# Altium schematic sheet 2_USB_UART.SchDoc(2_USB_UART)
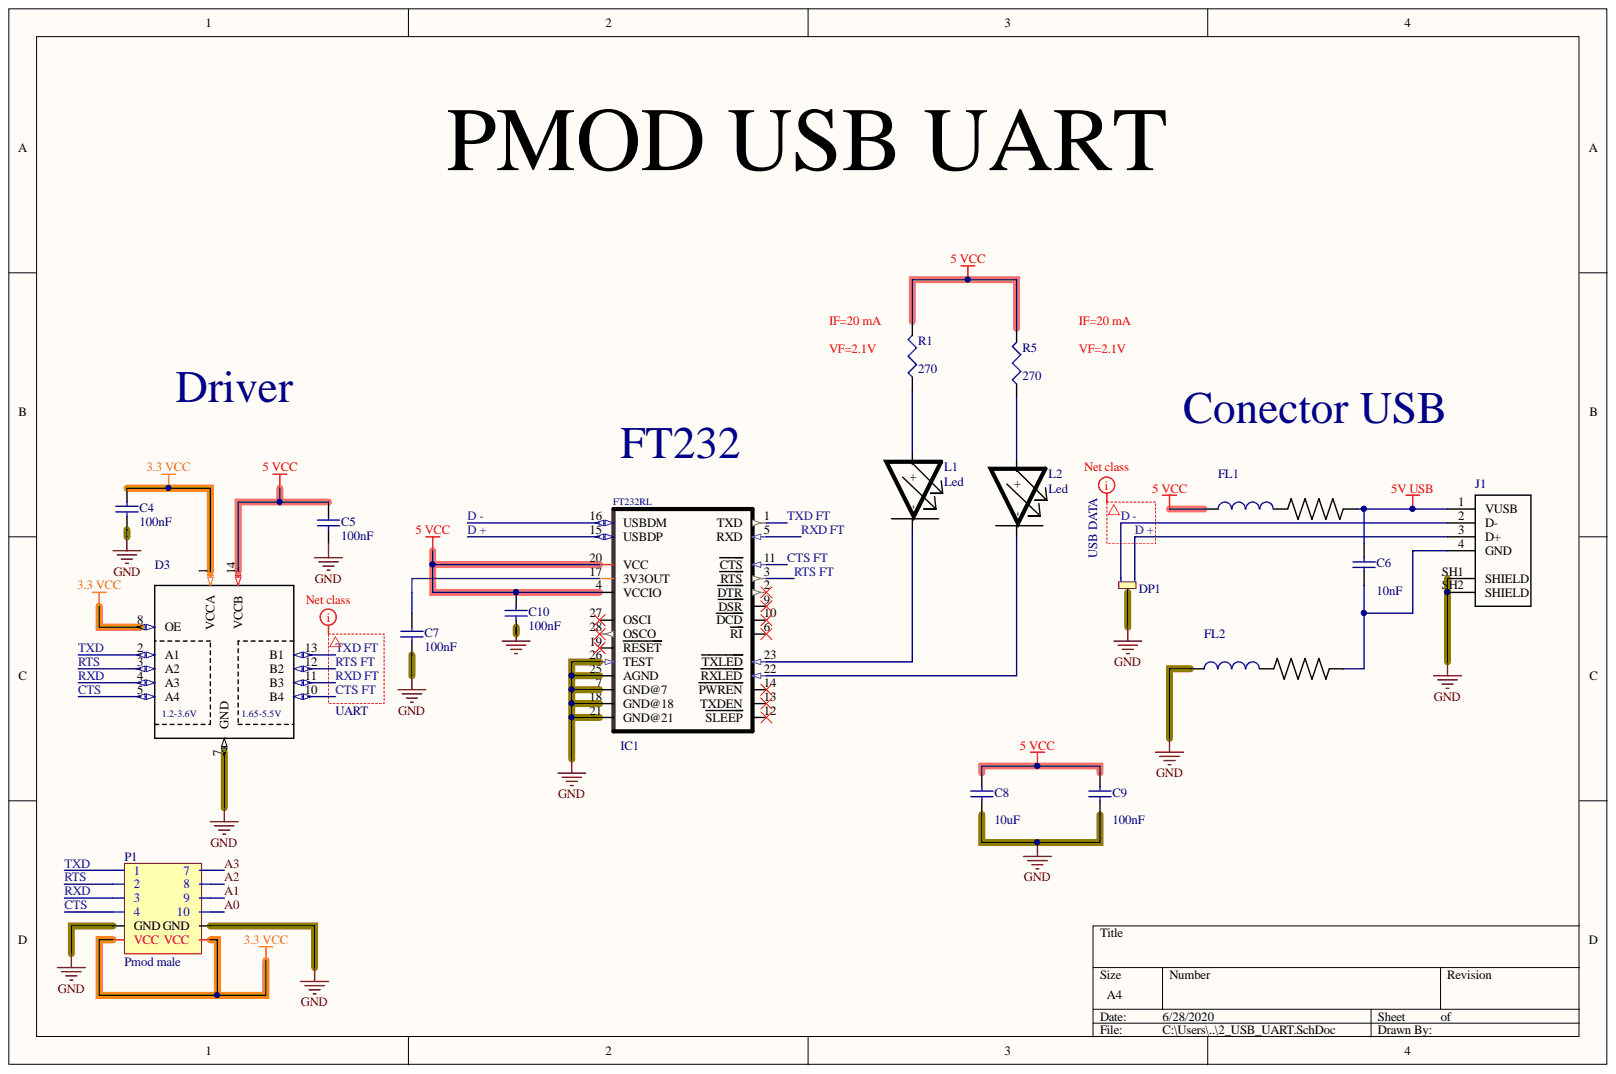
[diagram p0: 2_USB_UART.SchDoc]

## Parts
C4  value 100nF  [1608[0603]]  (hidden comment: Cap Semi)
C5  value 100nF  [1608[0603]]  (hidden comment: Cap Semi)
C6  value 10nF  [1608[0603]]  (hidden comment: Cap Semi)
C7  value 100nF  [1608[0603]]  (hidden comment: Cap Semi)
C8  value 10uF  [1608[0603]]  (hidden comment: Cap Semi)
C9  value 100nF  [1608[0603]]  (hidden comment: Cap Semi)
C10  value 100nF  [1608[0603]]  (hidden comment: Cap Semi)
D3  TXB0104PWR  [*TSSOP14]  (value not drawn)
DP1  PESD5V0U2BT,215  [*SOT95P230X110-3N]  (value not drawn)
FL1  BLM21PG221SN1D  [*BEADC2012X105N]  (value not drawn)
FL2  BLM21PG221SN1D  [*BEADC2012X105N]  (value not drawn)
IC1  FT232RL  [*SSOP28-LD]
J1  1-1734346-1  [*TE_1-1734346-1_1-1734346-1(Primary)]  (value not drawn)
L1  Led  [Diode1206 - PIN LETTER]
L2  Led  [Diode1206 - PIN LETTER]
P1  Pmod male  [901220766]
R1  270R  value 270  [J1-0603]
R5  270R  value 270  [J1-0603]

## Nets
3.3 VCC: C4.1 D3.1(VCCA) D3.8(OE) P1.6(VCC) P1.12(VCC)
5 VCC: C5.1 C8.2 C9.2 C10.1 D3.14(VCCB) FL1.1 IC1.4(VCCIO) IC1.20(VCC) R1.2 R5.2
5V USB: C6.2 FL1.2 J1.1(VUSB)
GND: C4.2 C5.2 C7.2 C8.1 C9.1 C10.2 D3.7(GND) DP1.3 FL2.1 IC1.7(GND@7) IC1.18(GND@18) IC1.21(GND@21) IC1.25(AGND) IC1.26(TEST) J1.SH1(SHIELD) J1.SH2(SHIELD) P1.5(GND) P1.11(GND)
A0: P1.10
A1: P1.9
A2: P1.8
A3: P1.7
CTS: D3.5(A4) P1.4
CTS FT: D3.10(B4) IC1.11(CTS)
D +: DP1.1 IC1.15(USBDP) J1.3(D+)
D -: DP1.2 IC1.16(USBDM) J1.2(D-)
RTS: D3.3(A2) P1.2
RTS FT: D3.12(B2) IC1.3(RTS)
RXD: D3.4(A3) P1.3
RXD FT: D3.11(B3) IC1.5(RXD)
TXD: D3.2(A1) P1.1
TXD FT: D3.13(B1) IC1.1(TXD)
NetC6_1: C6.1 FL2.2 J1.4(GND)
NetC7_1: C7.1 IC1.17(3V3OUT)
NetIC1_22: IC1.22(RXLED) L2.K
NetIC1_23: IC1.23(TXLED) L1.K
NetL1_A: L1.A R1.1
NetL2_A: L2.A R5.1
N00058:
N00059:

Not connected: IC1 2(DTR) 6(RI) 9(DSR) 10(DCD) 12(SLEEP) 13(TXDEN) 14(PWREN) 19(RESET) 27(OSCI) 28(OSCO)

## Library data of the parts
C4-C10 = Cap Semi: Capacitor (Semiconductor SIM Model); Simulation: CAP; Signal Integrity: Cap Semi; Published: 8-Jun-2000; PackageReference: C1206; PackageDescription: Chip Capacitor; Library Name: Miscellaneous Devices.IntLib; LatestRevisionNote: IPC-7351 Footprint Added.; LatestRevisionDate: 29-May-2009; Component Kind: Standard; Publisher: Altium Limited
D3 = TXB0104PWR: 4-Bit Bidirectional Voltage-Level Shifter with Auto Direction Sensing and +/-15 kV ESD Protect 14-TSSOP -40 to 85; MF: Texas Instruments; SnapEDA_Link: https://www.snapeda.com/parts/TXB0104PWR/Texas%20Instruments/view-part/?ref=snap; Price: None; Package: TSSOP-14 Texas Instruments; MP: TXB0104PWR; Library Name: Schlib1.SchLib; Digi-KeyReference: 296-21929-1-ND; Component Kind: Standard; Availability: Unavailable
DP1 = PESD5V0U2BT,215: TVS DIODE 5V SOT23; Manufacturer 1: Nexperia; Supplier Part Number 1: 1727-3936-1-ND; Supplier 1: Digi-Key; Stock 1: 61061; RoHS: No; Pricing 1: 1=0,44000, 10=0,35300, 100=0,24020, 500=0,18012, 1000=0,13510 (USD); Manufacturer Part Number 1: PESD5V0U2BT,215; Library Name: Schlib1.SchLib; Digi-KeyReference: 1727-3936-1-ND; ComponentLink1URL: https://assets.nexperia.com/documents/data-sheet/PESD5V0U2BT.pdf?src-supplier=Digi-Key; ComponentLink1Description: https://assets.nexperia.com/documents/data-sheet/PESD5V0U2BT.pdf?src-supplier=Digi-Key; Component Kind: Standard
FL1 FL2 = BLM21PG221SN1D: 220 Ohms @ 100MHz 1 Power Line Ferrite Bead 0805 (2012 Metric) 2A 45mOhm; MF: Murata; SnapEDA_Link: https://www.snapeda.com/parts/BLM21PG221SN1D/Murata/view-part/12270/?ref=snap; Package: 2012 Murata; MP: BLM21PG221SN1D; Library Name: Schlib1.SchLib; Digi-Key_Part_Number: 490-1054-1-ND; Component Kind: Standard
IC1 = FT232RL: USB-to-UART 1-CH 256Byte FIFO 5V 28-Pin SSOP; MF: FTDI, Future; SnapEDA_Link: https://www.snapeda.com/parts/FT232RL/FTDI%2C%20Future%20Technology%20Devices%20International%20Ltd/view-part/?ref=snap; Price: None; Package: SSOP-28 FTDI, Future; MP: FT232RL; Library Name: Schlib1.SchLib; Digi-KeyReference: 768-1007-1-ND; Component Kind: Standard; Availability: Unavailable
J1 = 1-1734346-1: Conn USB 2.0 Type B RCP 4 POS 1.25mm Solder RA SMD 4 Terminal 1 Port T/R; MF: TE Connectivity; SnapEDA_Link: https://www.snapeda.com/parts/1-1734346-1/TE%20Connectivity%20AMP%20Connectors/view-part/512451/?ref=snap; Package: None; MP: 1-1734346-1; Library Name: Schlib1.SchLib; EU_RoHS_Compliance: Compliant; DigiKey-Purchase-URL: https://snapeda.com/shop?store=DigiKey&id=512451; Digi-Key_Part_Number: A110862CT-ND; Component Kind: Standard
P1 = Pmod male: p mod male 6 position 2 rows; Library Name: Schlib1.SchLib; Component Kind: Standard
R1 R5 = Res3: Resistor; Simulation: RESISTOR; Signal Integrity: Res; Published: 8-Jun-2000; PackageReference: J1-0603; PackageDescription: Chip Resistor; Library Name: Miscellaneous Devices.IntLib; LatestRevisionNote: IPC-7351 Footprint Added.; LatestRevisionDate: 29-May-2009; Component Kind: Standard; Publisher: Altium Limited
L1 L2 = Led: Library Name: Schlib1.SchLib; Digi-KeyReference: 732-13395-1-ND; Component Kind: Standard
